# Altium schematic sheet pyController.SchDoc(pyController)
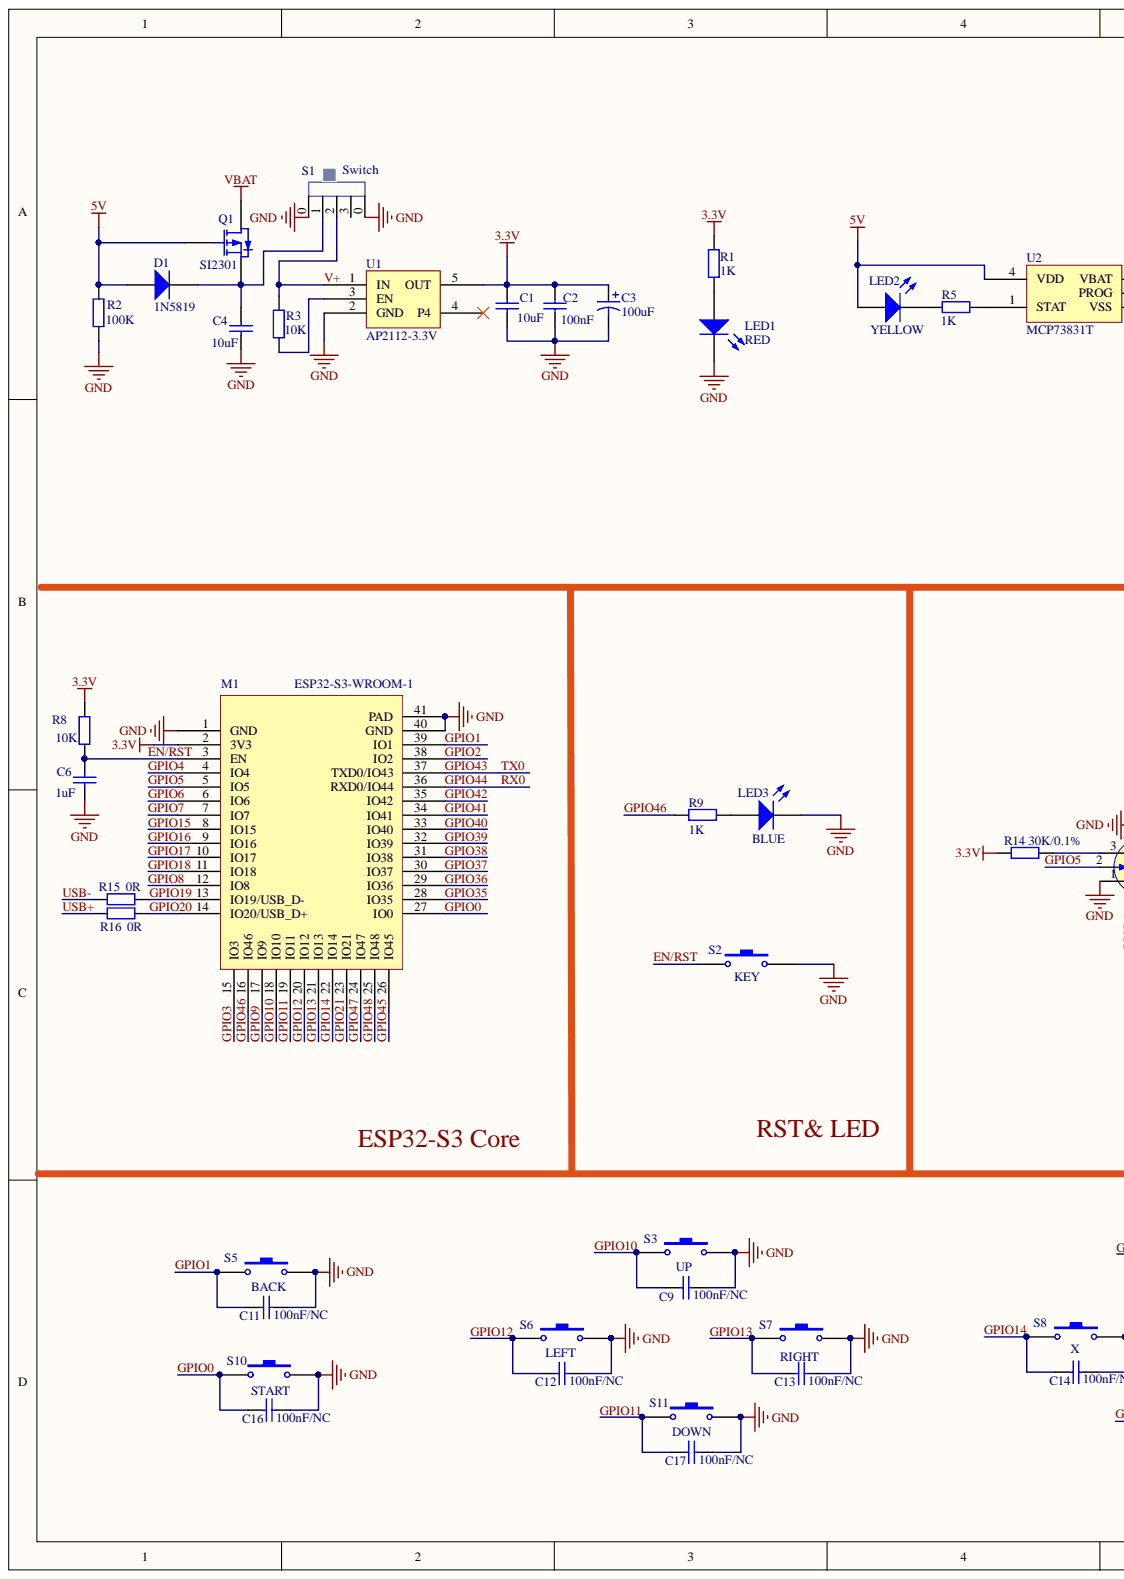
[diagram p0: pyController.SchDoc, left]
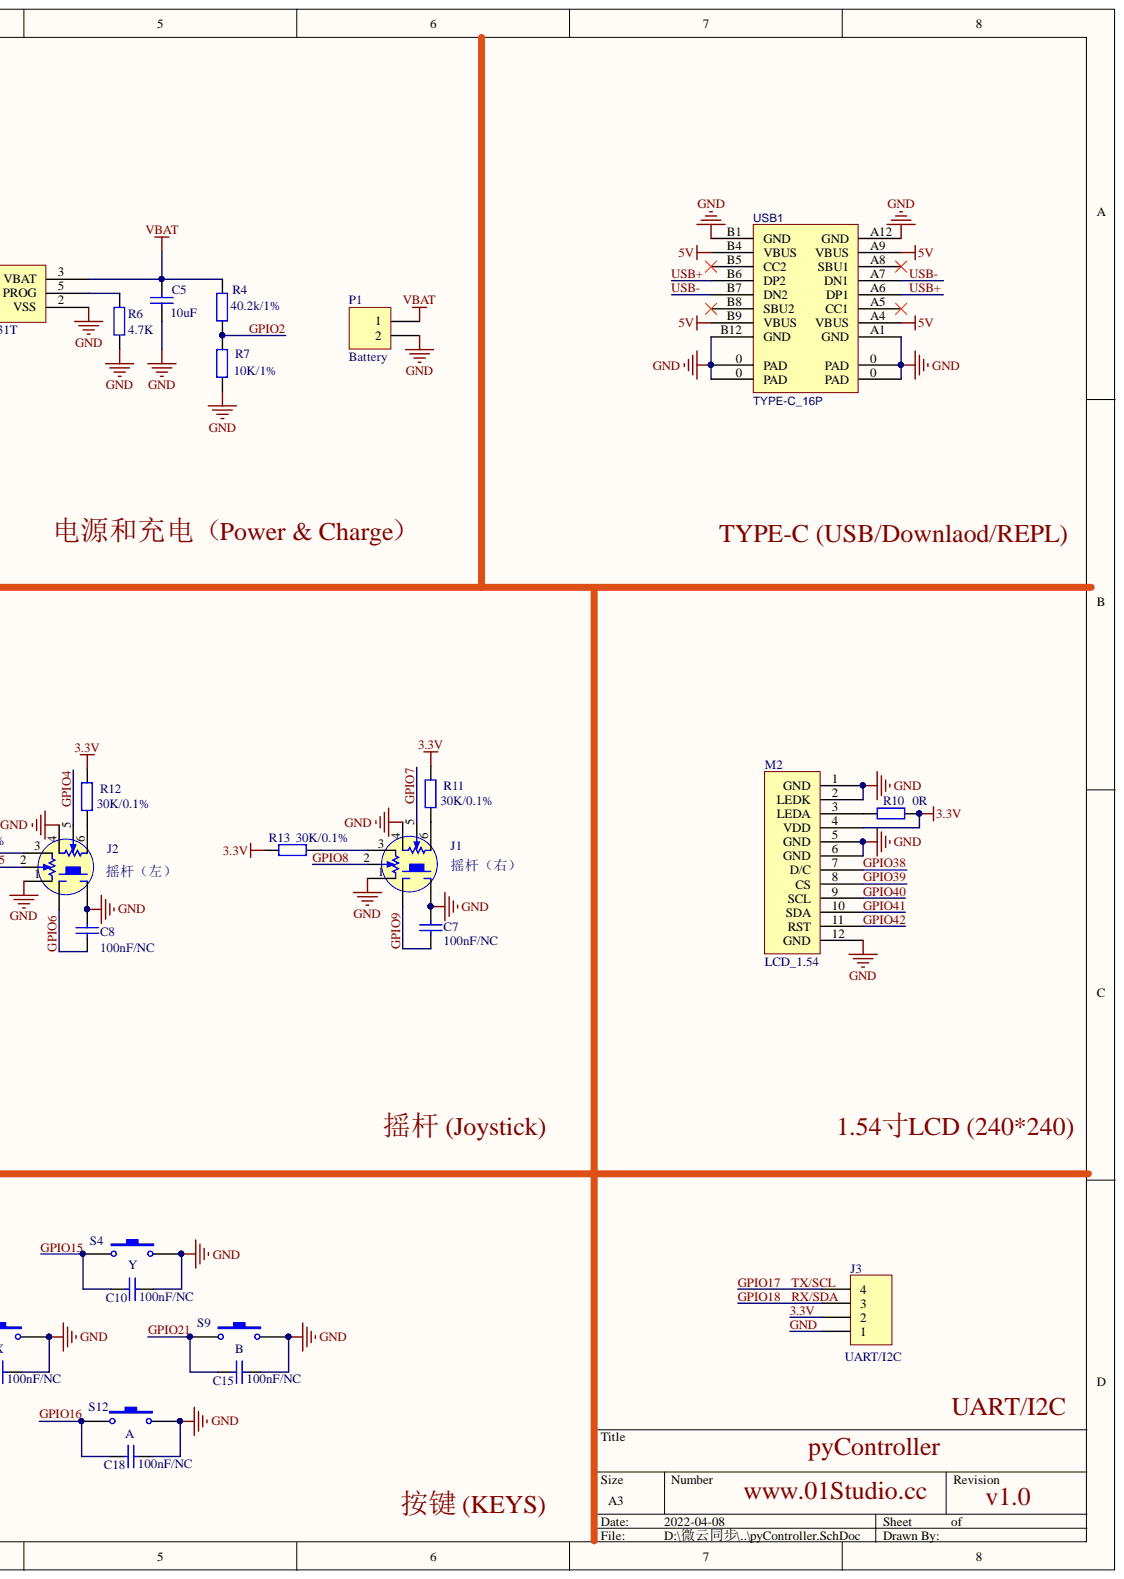
[diagram p1: pyController.SchDoc, right]

## Parts
C1  10uF  [0603P]
C2  100nF  [0603P]
C3  100uF  [B型钽电容]
C4  10uF  [0603P]
C5  10uF  [0603P]
C6  1uF  [0603P]
C7  100nF/NC  [0603P]
C8  100nF/NC  [0603P]
C9  100nF/NC  [0603P]
C10  100nF/NC  [0603P]
C11  100nF/NC  [0603P]
C12  100nF/NC  [0603P]
C13  100nF/NC  [0603P]
C14  100nF/NC  [0603P]
C15  100nF/NC  [0603P]
C16  100nF/NC  [0603P]
C17  100nF/NC  [0603P]
C18  100nF/NC  [0603P]
D1  1N5819  [SOD-323]
J1  摇杆（右）  [摇杆2]
J2  摇杆（左）  [摇杆2]
J3  UART/I2C  [CONN-SMD_4P-P1.25-HC-1.25-4PWT]
LED1  RED  [D0603]
LED2  YELLOW  [D0603]
LED3  BLUE  [D0603]
M1  ESP32-S3-WROOM-1  [ESP32-S3-WROOM-1]
M2  LCD_1.54  [1.54寸LCD]
P1  Battery  [PH2.0-弯针-2P]
Q1  SI2301  [SOT-23]
R1  1K  [0603P]
R2  100K  [0603P]
R3  10K  [0603P]
R4  40.2k/1%  [0603P]
R5  1K  [0603P]
R6  4.7K  [0603P]
R7  10K/1%  [0603P]
R8  10K  [0603P]
R9  1K  [0603P]
R10  0R  [0603P]
R11  30K/0.1%  [0603P]
R12  30K/0.1%  [0603P]
R13  30K/0.1%  [0603P]
R14  30K/0.1%  [0603P]
R15  0R  [0603P]
R16  0R  [0603P]
S1  Switch  [MSK-12C02]
S2  KEY  [贴片按键/3.2*4.2*2.5mm]
S3  UP  [贴片大按键]
S4  Y  [贴片大按键]
S5  BACK  [贴片按键/3.2*4.2*2.5mm]
S6  LEFT  [贴片大按键]
S7  RIGHT  [贴片大按键]
S8  X  [贴片大按键]
S9  B  [贴片大按键]
S10  START  [贴片按键/3.2*4.2*2.5mm]
S11  DOWN  [贴片大按键]
S12  A  [贴片大按键]
U1  AP2112-3.3V  [SOT23-5]
U2  MCP73831T  [SOT23-5]
USB1  TYPE-C_16P  [USB-TYPE-C_16PIN-MALE-TYPE-C-31-M-12]

## Nets
5V: D1.1 LED2.1 Q1.1 R2.1 U2.4(VDD) USB1.A4(VBUS) USB1.A9(VBUS) USB1.B4(VBUS) USB1.B9(VBUS)
VBAT: C5.2 P1.1 Q1.3 R4.2 U2.3(VBAT)
3.3V: C1.2 C2.2 C3.1 J3.2 M1.2(3V3) M2.4(VDD) R1.1 R8.2 R10.1 R11.2 R12.2 R13.2 R14.2 U1.5(OUT)
EN/RST: C6.2 M1.3(EN) R8.1 S2.1
GND: C1.1 C2.1 C3.2 C4.1 C5.1 C6.1 C7.1 C8.1 C9.1 C10.1 C11.1 C12.1 C13.1 C14.1 C15.1 C16.1 C17.1 C18.1 J1.1 J1.4 J1.J1 J2.1 J2.4 J2.J1 J3.1 LED1.2 LED3.2 M1.1(GND) M1.40(GND) M1.41(PAD) M2.1(GND) M2.2(LEDK) M2.5(GND) M2.6(GND) M2.12(GND) P1.2 R2.2 R6.1 R7.1 S1.0 S2.2 S3.2 S4.2 S5.2 S6.2 S7.2 S8.2 S9.2 S10.2 S11.2 S12.2 U1.2(GND) U2.2(VSS) USB1.0(PAD) USB1.A1(GND) USB1.A12(GND) USB1.B1(GND) USB1.B12(GND)
GPIO0: C16.2 M1.27(IO0) S10.1
GPIO1: C11.2 M1.39(IO1) S5.1
GPIO2: M1.38(IO2) R4.1 R7.2
GPIO3: M1.15(IO3)
GPIO4: J2.5 M1.4(IO4)
GPIO5: J2.2 M1.5(IO5)
GPIO6: C8.2 J2.J2 M1.6(IO6)
GPIO7: J1.5 M1.7(IO7)
GPIO8: J1.2 M1.12(IO8)
GPIO9: C7.2 J1.J2 M1.17(IO9)
GPIO10: C9.2 M1.18(IO10) S3.1
GPIO11: C17.2 M1.19(IO11) S11.1
GPIO12: C12.2 M1.20(IO12) S6.1
GPIO13: C13.2 M1.21(IO13) S7.1
GPIO14: C14.2 M1.22(IO14) S8.1
GPIO15: C10.2 M1.8(IO15) S4.1
GPIO16: C18.2 M1.9(IO16) S12.1
GPIO17: J3.4 M1.10(IO17)
GPIO18: J3.3 M1.11(IO18)
GPIO19: M1.13(IO19/USB_D-) R15.1
GPIO20: M1.14(IO20/USB_D+) R16.1
GPIO21: C15.2 M1.23(IO21) S9.1
GPIO35: M1.28(IO35)
GPIO36: M1.29(IO36)
GPIO37: M1.30(IO37)
GPIO38: M1.31(IO38) M2.7(D/C)
GPIO39: M1.32(IO39) M2.8(CS)
GPIO40: M1.33(IO40) M2.9(SCL)
GPIO41: M1.34(IO41) M2.10(SDA)
GPIO42: M1.35(IO42) M2.11(RST)
GPIO43: M1.37(TXD0/IO43)
GPIO44: M1.36(RXD0/IO44)
GPIO45: M1.26(IO45)
GPIO46: M1.16(IO46) R9.1
GPIO47: M1.24(IO47)
GPIO48: M1.25(IO48)
USB+: R16.2 USB1.A6(DP1) USB1.B6(DP2)
USB-: R15.2 USB1.A7(DN1) USB1.B7(DN2)
V+: R3.1 S1.2 U1.1(IN)
NetC4_2: C4.2 D1.2 Q1.2 S1.1
NetJ1_3: J1.3 R13.1
NetJ1_6: J1.6 R11.1
NetJ2_3: J2.3 R14.1
NetJ2_6: J2.6 R12.1
NetLED1_1: LED1.1 R1.2
NetLED2_2: LED2.2 R5.1
NetLED3_1: LED3.1 R9.2
NetM2_3: M2.3(LEDA) R10.2
NetR3_2: R3.2 U1.3(EN)
NetR5_2: R5.2 U2.1(STAT)
NetR6_2: R6.2 U2.5(PROG)

Not connected: S1 3; U1 4(P4); USB1 A5(CC1) A8(SBU1) B5(CC2) B8(SBU2)

## Library data of the parts
C1 C2 C4-C18 = Cap: Capacitor; Simulation: CAP; Signal Integrity: Cap; Published: 8-Jun-2000; PackageReference: RAD-0.3; Library Name: Miscellaneous Devices.IntLib; LatestRevisionNote: Re-released for DXP Platform.; LatestRevisionDate: 17-Jul-2002; Component Kind: Standard; Publisher: Altium Limited
C3 = Cap Pol1: Polarized Capacitor (Radial); Simulation: CAP; Signal Integrity: Cap Pol; Published: 8-Jun-2000; PackageReference: RB7.6-15; Library Name: Miscellaneous Devices.IntLib; LatestRevisionNote: Re-released for DXP Platform.; LatestRevisionDate: 17-Jul-2002; Component Kind: Standard; Publisher: Altium Limited
D1 = Diode: Default Diode; Simulation: DIODE; Signal Integrity: Diode; Published: 8-Jun-2000; PackageReference: SMC; PackageDocument: Sep-1996; Library Name: Miscellaneous Devices.IntLib; LatestRevisionNote: Re-released for DXP Platform.; LatestRevisionDate: 17-Jul-2002; Component Kind: Standard; Code_JEDEC: DO-214-AB; Publisher: Altium Limited
J1 J2 = 摇杆: Library Name: Schlib1.SchLib; Description: 摇杆; Component Kind: Standard
J3 = Header 4: Header, 4-Pin; Signal Integrity: Connector; Library Name: Miscellaneous Connectors.IntLib; LatestRevisionNote: Re-released for DXP Platform.; LatestRevisionDate: 17-Jul-2002; Component Kind: Standard; Publisher: Altium Limited
LED1-LED3 = LED1: Typical RED GaAs LED; Simulation: LED1; Published: 8-Jun-2000; PackageReference: LED-1; Library Name: Miscellaneous Devices.IntLib; LatestRevisionNote: Mech Layer 1 removed.; LatestRevisionDate: 08-Jul-2005; Component Kind: Standard; Publisher: Altium Limited
P1 = MHDR1X2: Header, 2-Pin; Signal Integrity: Connector; Library Name: Miscellaneous Connectors.IntLib; LatestRevisionNote: Re-released for DXP Platform.; LatestRevisionDate: 17-Jul-2002; Component Kind: Standard; Publisher: Altium Limited
Q1 = P-MOS: Library Name: WeBee.SCHLIB; Component Kind: Standard
R1-R16 = Res2: Resistor; Simulation: RESISTOR; Signal Integrity: Res; Published: 8-Jun-2000; PackageReference: AXIAL-0.4; Library Name: Miscellaneous Devices.IntLib; LatestRevisionNote: Re-released for DXP Platform.; LatestRevisionDate: 17-Jul-2002; Component Kind: Standard; Publisher: Altium Limited
S1 = MSK-12C01-07开关: Library Name: 01Studio.SchLib; Component Kind: Standard
S2-S12 = SW-PB: Switch; Published: 24-Mar-1999; PackageReference: SPST-2; Library Name: Miscellaneous Devices.IntLib; LatestRevisionNote: Re-released for DXP Platform.; LatestRevisionDate: 17-Jul-2002; Component Kind: Standard; Publisher: Altium Limited
U1 = AP2112: Library Name: 01Studio.SchLib; Component Kind: Standard
USB1 = USB3-TypeC-16p-2: Component Kind: Standard
M1 = ESP32-S3-WROOM-1: Library Name: 01Studio.SchLib; Component Kind: Standard
M2 = LCD_1.54: Library Name: Schlib1.SchLib; Component Kind: Standard
U2 = MCP73831T: Component Kind: Standard
